# Altium schematic sheet Schematic.SchDoc
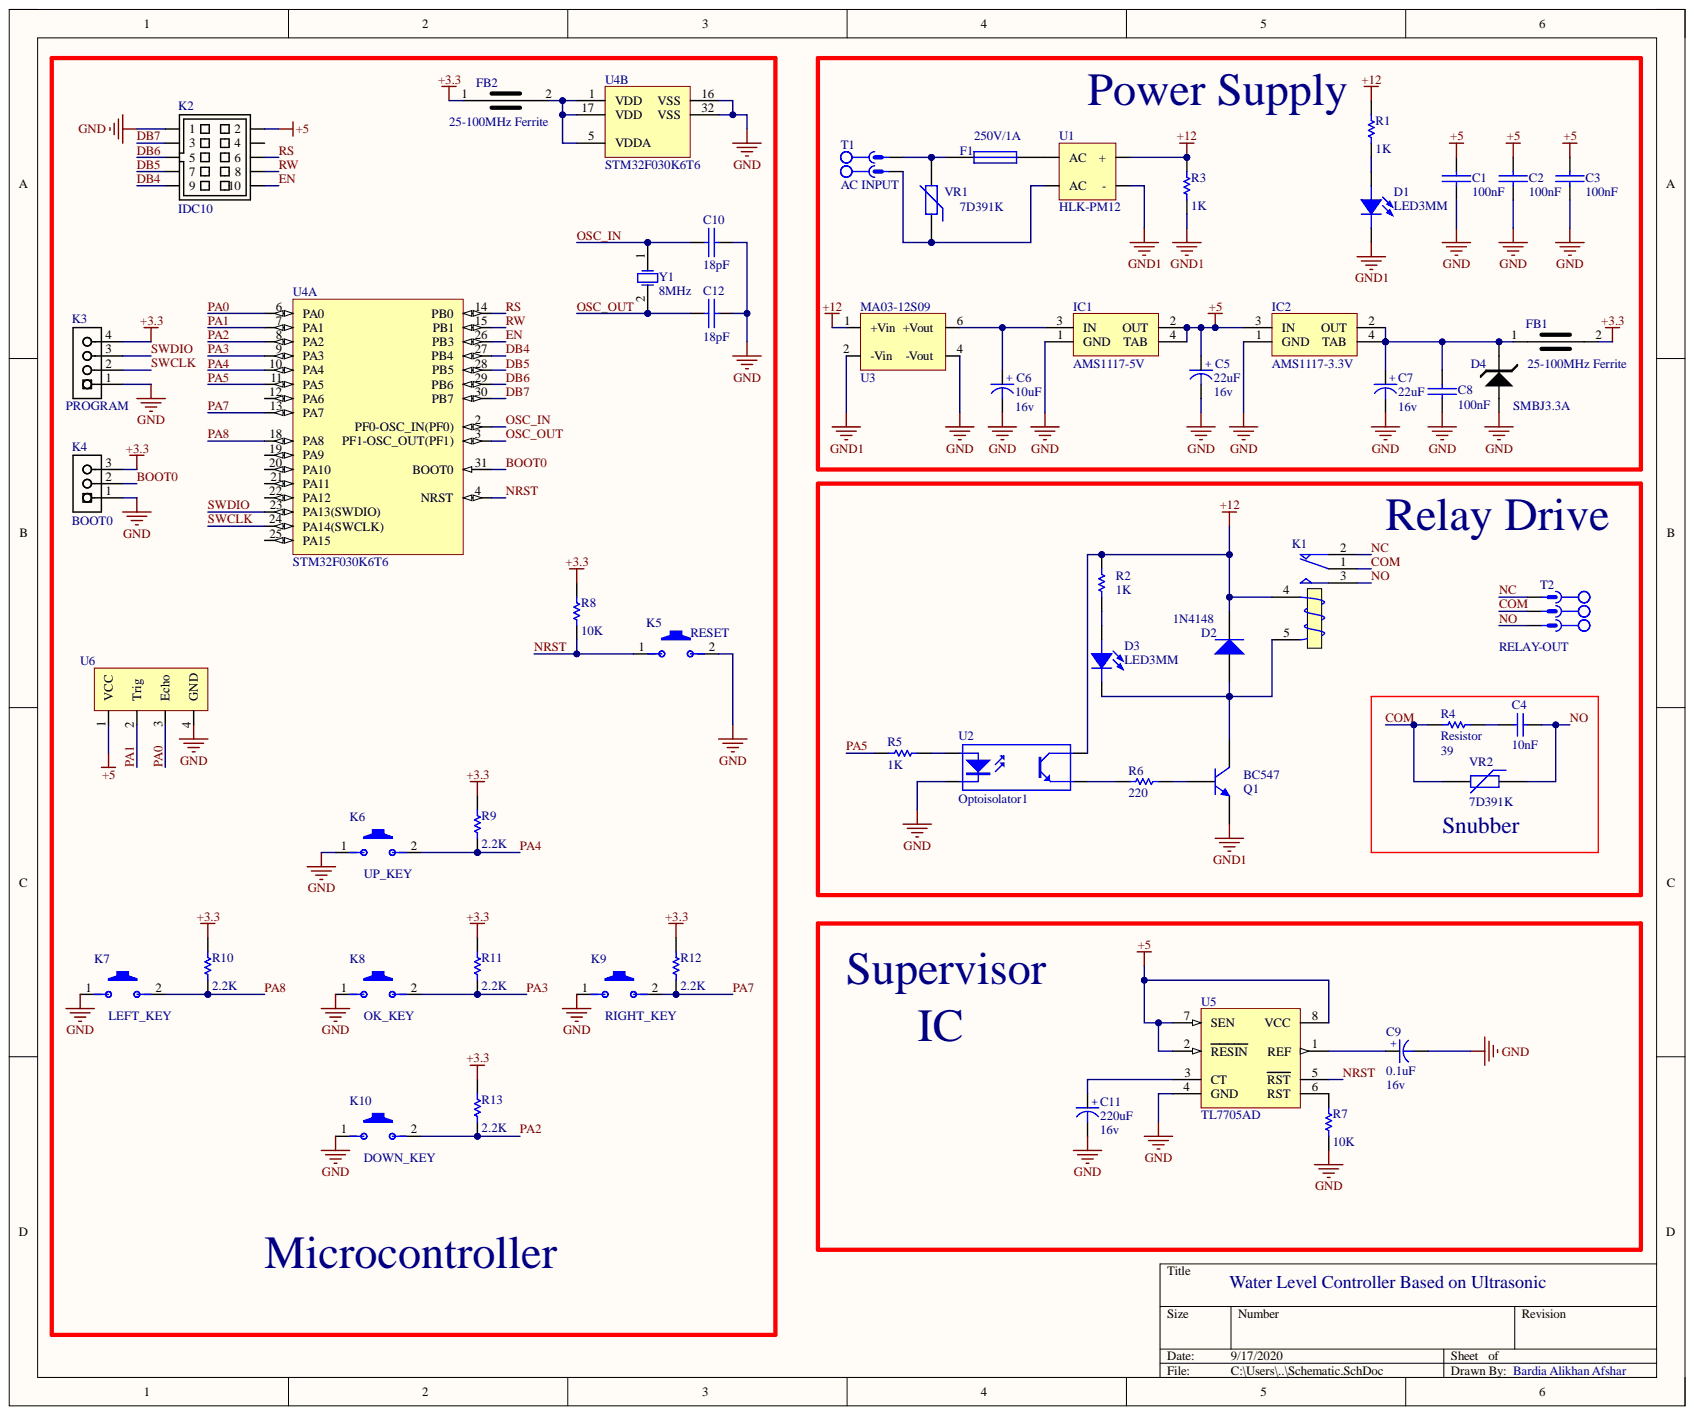
[diagram p0: Schematic.SchDoc]

## Parts
C1  value 100nF  [Cap_100nF]  (hidden comment: Capacitor.)
C2  value 100nF  [Cap_100nF]  (hidden comment: Capacitor.)
C3  value 100nF  [Cap_100nF]  (hidden comment: Capacitor.)
C4  10nF  [Cap-100nF-400V]
C5  value 22uF  Voltage: 16v  [cap-ele-02.]  (hidden comment: Capacitor_Ele)
C6  value 10uF  Voltage: 16v  [cap-ele-02.]  (hidden comment: Capacitor_Ele)
C7  value 22uF  Voltage: 16v  [cap-ele-02.]  (hidden comment: Capacitor_Ele)
C8  value 100nF  [Cap_100nF]  (hidden comment: Capacitor.)
C9  value 0.1uF  Voltage: 16v  [cap-ele-02.]  (hidden comment: Capacitor_Ele)
C10  value 18pF  [Cap_100nF]  (hidden comment: Capacitor.)
C11  value 220uF  Voltage: 16v  [Cap-Ele-03.]  (hidden comment: Capacitor_Ele)
C12  value 18pF  [Cap_100nF]  (hidden comment: Capacitor.)
D1  LED3MM  [LED3mm_GRN]
D2  1N4148  [DO_41]
D3  LED3MM  [LED3mm_GRN]
D4  SMBJ3.3A  [DO214AA]
F1  250V/1A  [PIN-W2/E2.8]
FB1  25-100MHz Ferrite  [Ferrite]
FB2  25-100MHz Ferrite  [Ferrite]
IC1  AMS1117-5V  [MP04A_N]
IC2  AMS1117-3.3V  [MP04A_N]
K1  (no value)  [Relay 18.85x15.35x15.70]
K2  IDC10  [IDC10-B]
K3  PROGRAM  [HEADER-1X4]
K4  BOOT0  [HEADER-1X3]
K5  RESET  [SW_4]
K6  UP_KEY  [SW_4]
K7  LEFT_KEY  [SW_4]
K8  OK_KEY  [SW_4]
K9  RIGHT_KEY  [SW_4]
K10  DOWN_KEY  [SW_4]
Q1  BC547  [TO-92 BENT]
R1  Resistor  value 1K  [Resistor_0.25w-0.3]
R2  Resistor  value 1K  [Resistor_0.25w-0.3]
R3  Resistor  value 1K  [Resistor_0.25w-0.3]
R4  Resistor  value 39  [Resistor_0.5W]
R5  Resistor  value 1K  [Resistor_0.25w-0.3]
R6  Resistor  value 220  [Resistor_0.25w-0.3]
R7  Resistor  value 10K  [Resistor_0.25w-0.3]
R8  Resistor  value 10K  [Resistor_0.25w-0.3]
R9  Resistor  value 2.2K  [Resistor_0.25w-0.3]
R10  Resistor  value 2.2K  [Resistor_0.25w-0.3]
R11  Resistor  value 2.2K  [Resistor_0.25w-0.3]
R12  Resistor  value 2.2K  [Resistor_0.25w-0.3]
R13  Resistor  value 2.2K  [Resistor_0.25w-0.3]
T1  AC INPUT  [MSTB 2,5/2-G-5,08]
T2  RELAY-OUT  [MSTB 2,5/3-G-5,08]
U1  HLK-PM12  [HLK-PM]
U2  Optoisolator1  [DIP-4]
U3  MA03-12S09  [MA03-12S09]
U4  STM32F030K6T6  [LQFP32]  (parts A,B)
U5  TL7705AD  [D008_N]
U6  JSN-SR04T  [JSN-SR04T-H]  (value not drawn)
VR1  7D391K  [07D XXX]
VR2  7D391K  [07D XXX]
Y1  8MHz  [XTAL Small]

## Nets
+3.3: FB1.2 FB2.1 K3.4 K4.3 R8.2 R9.2 R10.2 R11.2 R12.2 R13.2
+5: C1.1 C2.1 C3.1 C5.1 IC1.2 IC1.4 IC2.3 K2.2 U5.2 U5.7 U5.8 U6.1
+12: D2.2 K1.4 R1.2 R2.2 R3.2 U1.2 U2.4 U3.1
GND: C1.2 C2.2 C3.2 C5.2 C6.2 C7.2 C8.2 C9.2 C10.2 C11.2 C12.2 D4.A IC1.1 IC2.1 K2.1 K3.1 K4.1 K5.2 K6.1 K7.1 K8.1 K9.1 K10.1 R7.1 U2.2 U3.4 U4.16 U4.32 U5.4 U6.4
GND1: D1.2 Q1.3 R3.1 U1.3 U3.2
BOOT0: K4.2 U4.31
COM: K1.1 R4.1 T2.2 VR2.2
DB4: K2.9 U4.27
DB5: K2.7 U4.28
DB6: K2.5 U4.29
DB7: K2.3 U4.30
EN: K2.10 U4.26
NC: K1.2 T2.1
NO: C4.1 K1.3 T2.3 VR2.1
NRST: K5.1 R8.1 U4.4 U5.5
OSC_IN: C10.1 U4.2 Y1.1
OSC_OUT: C12.1 U4.3 Y1.2
PA0: U4.6 U6.3
PA1: U4.7 U6.2
PA2: K10.2 R13.1 U4.8
PA3: K8.2 R11.1 U4.9
PA4: K6.2 R9.1 U4.10
PA5: R5.2 U4.11
PA7: K9.2 R12.1 U4.13
PA8: K7.2 R10.1 U4.18
RS: K2.6 U4.14
RW: K2.8 U4.15
SWCLK: K3.2 U4.24
SWDIO: K3.3 U4.23
NetC4_2: C4.2 R4.2
NetC6_1: C6.1 IC1.3 U3.6
NetC7_1: C7.1 C8.1 D4.K FB1.1 IC2.2 IC2.4
NetC9_1: C9.1 U5.1
NetC11_1: C11.1 U5.3
NetD1_1: D1.1 R1.1
NetD2_1: D2.1 D3.2 K1.5 Q1.1
NetD3_1: D3.1 R2.1
NetF1_1: F1.1 T1.2 VR1.2
NetF1_2: F1.2 U1.0
NetFB2_2: FB2.2 U4.1 U4.5 U4.17
NetQ1_2: Q1.2 R6.1
NetR5_1: R5.1 U2.1
NetR6_2: R6.2 U2.3
NetR7_2: R7.2 U5.6
NetT1_1: T1.1 U1.1 VR1.1

Not connected: K2 4; U4 12 19 20 21 22 25

## Library data of the parts
C1-C3 C8 C10 C12 = Capacitor.: Capacitor; Component Kind: Standard; LatestRevisionDate: 17-Jul-2002; LatestRevisionNote: Re-released for DXP Platform.; Library Name: A.Mohebbi.IntLib; Publisher: Altium Limited
C4 = CAP CERAMIC TOTAL SIZE: 10nF/400V Polyester - DIP; Designer: Bardia Alikhan Afshar; Component Kind: Standard; Library Name: Altium.IntLib
C5-C7 C9 C11 = Capacitor_Ele.: Component Kind: Standard; Description: Capacitor_Ele; LatestRevisionDate: 17-Jul-2002; LatestRevisionNote: Re-released for DXP Platform.; Library Name: A.Mohebbi.IntLib; Publisher: Altium Limited
D1 D3 = LED 3MM: Light Emitting Diode; Designer: Bardia Alikhan Afshar; Component Kind: Standard; Library Name: Altium.IntLib
D2 = Diode_1N4007: Diode 1N4007; Component Kind: Standard; LatestRevisionDate: 17-Jul-2002; LatestRevisionNote: Re-released for DXP Platform.; Library Name: A.Mohebbi.IntLib; Publisher: Altium Limited
D4 = SMBJ12AVCL: Transient Surpression Diode (Transil); Manufacturer: STMicroelectronics; Manufacturer Part Number: SMBJ12CA-TR; Component Kind: Standard; Library Name: vh_altium_library.intLib; Supplier 1: Digi-Key; Supplier Part Number 1: 497-3002-2-ND
F1 = Fuse 1: Fuse; Component Kind: Standard; LatestRevisionDate: 17-Jul-2002; LatestRevisionNote: Re-released for DXP Platform.; Library Name: Miscellaneous Devices.IntLib; PackageDescription: Thru-Hole; 2 Leads; PackageReference: PIN-W2/E2.8; Published: 8-Jun-2000; Simulation: FUSE; Publisher: Altium Limited
FB1 FB2 = Ferrite: Component Kind: Standard; Library Name: Bardia.SchLib
IC1 IC2 = IC_LM1117IMP-x.x: x.x Volt, 800mA Low-Dropout Linear Regulator, 4-pin SOT-223; Manufacturer: National Semiconductor; Designer: Bardia Alikhan Afshar; ESD Sensetive: True/False; PackageTopMarking: XYTT*N05B; Category: Integrated Circuit; Code_IPC: SOT223-4; Component Kind: Standard; ComponentLink1Description: Datasheet; ComponentLink1URL: www.datasheet4u.com; ComponentLink2Description: Reference; ComponentLink2URL: http://www.national.com/pf/LM/LM1117.html; DatasheetVersion: Apr-2006; Library Name: SJ Altium Library.IntLib; Mounting Technology: Surface Mount; Operating Temperature: -55 ~ +125 (C); PackageDescription: Molded SOT-223, 6.50 x 3.56 x 1.6mm Body, 4 Lead, 2.3mm Pitch; PackageReference: MP04A; PinCount: 4; RoHS compliant: True/False; Status: Unrelease; Update: 6/5/2012
K1 = Relay_SPDT: Single-Pole Dual-Throw Relay; Designer: Bardia Alikhan Afshar; Component Kind: Standard; ComponentLink1Description: Datasheet; Mounting Technology: Through-Hole; Pin Count: 0; Update Date: 2017-3-1; Library Name: 2017AbbasBasereh9365731239 -ver1.IntLib
K2 = IDC10: BOXHEADER 10 PIN; Manufacturer Part Number: AWHW10G-0202-T-R; Connector Type: Header, Shrouded; Number of Positions: 10; Number of Positions Loaded: All; Pitch: 0.100" (2.54mm); Category: Connectors, Interconnects; Color: Gray; Component Kind: Standard; Contact Finish: Gold; Contact Finish Thickness: Flash; Contact Mating Length: -; Contact Type: Male Pin; Fastening Type: -; Features: -; Library Name: vh_altium_library.intLib; Mounting Type: Through Hole; Number of Rows: 2; Packaging: Tray; RoHS: RoHS Compliant; Row Spacing: 0.100" (2.54mm); Supplier: Digi-Key; Supplier 1: Digi-Key; Supplier Part Number: HRP10H-ND; Supplier Part Number 1: HRP10H-ND; Termination: Solder
K3 = HEADER-1X4: Component Kind: Standard; Library Name: vh_altium_library.intLib; Supplier 1: Digi-Key; Supplier Part Number 1: S1011E-36-ND
K4 = HEADER-1X3: Component Kind: Standard; Library Name: vh_altium_library.intLib; Supplier 1: Digi-Key; Supplier Part Number 1: S1011E-36-ND
K5-K10 = Push_Button: Design By: Bardia Alikhan Afshar; Component Kind: Standard; Library Name: Bardia.SchLib
Q1 = BC547: NPN General-purpose Transistor; Desc.: Plastic Medium-Power. Silicon NPN Transistors; Designer: Bardia Alikhan Afshar; Component Kind: Standard; Data: 27-12-2016; Library Name: Altium.IntLib; Part. No: BC547
R1-R3 R5-R13 = Resistor: Email: bardia.a.afshar@gmail.com; Component Kind: Standard; Description: Resistor; LatestRevisionNote: Re-released for puplic; Library Name: resistors.IntLib; Published: 17-Jun-2016; Publisher: Bardia Alikhan Afshar
R4 = Resistor: Email: bardia.a.afshar@gmail.com; Value: 39; Component Kind: Standard; Description: Resistor; LatestRevisionNote: Re-released for puplic; Library Name: resistors.IntLib; Published: 17-Jun-2016; Publisher: Bardia Alikhan Afshar
T1 = CON_Phoenix_MSTB_02: 2 Pitch: 5.08 mm Color: green Contact surface: Tin Assembly; Manufacturer: PHOENIX CONTACT; Connector type: PCB Terminal Block; designer: Bardia Alikhan Afshar; Max Current: 12 A; Positions: 2; Voltage: 320 V; Category: Connector; Component Kind: Standard; ComponentLink1Description: Header, Nominal current: 12 A Rated voltage (III/2): 320 V Number of positions: 2 Pitch: 5.08 mm Color: green Contact surface: Tin Assembly: Soldering  DESIGNER:ABBAS BASEREH; ComponentLink2Description: Reference; Mounting Technology: Through-Hole; Pin Count: 2; Revision 1: 2017-3-1; Signal Integrity: CON-2P; Status: Unreleased; Library Name: 2017AbbasBasereh9365731239 -ver1.IntLib
T2 = CON_Phoenix_MSTB_03: 3 Pitch: 5.08 mm Color: green Contact surface: Tin Assembly; Manufacturer: PHOENIX CONTACT; Connector type: PCB Terminal Block; designer: Bardia Alikhan Afshar; Max Current: 12 A; Positions: 3; Voltage: 320 V; Category: Connector; Component Kind: Standard; ComponentLink1Description: Header, Nominal current: 12 A Rated voltage (III/2): 320 V Number of positions: 3 Pitch: 5.08 mm Color: green Contact surface: Tin Assembly: Soldering  DESIGNER:ABBAS BASEREH; ComponentLink2Description: Reference; Mounting Technology: Through-Hole; Pin Count: 3; Revision 1: 2017-3-1; Signal Integrity: CON-3P; Status: Unreleased; Library Name: 2017AbbasBasereh9365731239 -ver1.IntLib
U1 = HLK-PM: AC/DC Converter; Component Kind: Standard; Library Name: Bardia.SchLib
U2 = Optoisolator1: 4-Pin Phototransistor Optocoupler; Component Kind: Standard; DatasheetVersion: Nov-2003; LatestRevisionDate: 04-Mar-2005; LatestRevisionNote: Sch pins 3 & 4 Swapped.; Library Name: Miscellaneous Devices.IntLib; PackageReference: DIP-4; Published: 24-Mar-1999; Publisher: Altium Limited
U3 = MA03-12S0x: DC/DC Converter; Component Kind: Standard; Library Name: Bardia.SchLib
U4 = STM32F030K6T6: ARM Cortex-M0 32-bit MCU, 32 KB Flash, 4 KB Internal RAM, 26 I/Os, 32-pin LQFP, -40 to 85 degC, Tray; FLASH Size (Prog) (kB): 32; I/Os (High Current): 26; Internal RAM Size (kB): 4; Operating Frequency(F) (Processor speed) (MHz): 48; Supply Voltage(Vcc) min (V): 2.4; Component Kind: Standard; ComponentLink1Description: Manufacturer URL; ComponentLink1URL: http://www.st.com/web/en/home.html; ComponentLink2Description: Datasheet; ComponentLink2URL: http://www.st.com/st-web-ui/static/active/en/resource/technical/document/datasheet/DM00088500.pdf; ComponentLink3Description: Package Specification; ComponentLink3URL: http://www.st.com/st-web-ui/static/active/en/resource/technical/document/datasheet/DM00088500.pdf; Core: ARM Cortex-M0; DatasheetVersion: Rev. 1; Mounting Technology: Surface Mount; PackageDescription: 32-Pin Low Profile Quad Flat Package, 7 x 7 mm Body, 0.8 mm Pitch; PackageReference: LQFP32; PackageVersion: Rev. 1, 07/2013; Packing: Tray; PartNumber: STM32F030K6T6; Supplier 1: Digi-Key; Supplier 2: Newark; Supplier 3: Mouser; Supplier Part Number 1: 497-14046-ND; Supplier Part Number 2: 19X6194; Supplier Part Number 3: 511-STM32F030K6T6; Library Name: Bardia.SchLib
U5 = TL7705AD: Supply Voltage Supervisor; Code_IPC: SOIC127P600-8; Component Kind: Standard; ComponentLink1Description: Manufacturer Link; ComponentLink1URL: http://www.ti.com/; DatasheetVersion: Oct-1988; LatestRevisionDate: 13-Apr-2006; LatestRevisionNote: IPC-7351 Footprint Added.; PackageDescription: 8-Pin Small Outline Integrated Circuit 1.27 mm Pitch; PackageReference: D008; PackageVersion: Jan-1998; Published: 15-Apr-2002; Library Name: Bardia.SchLib; Publisher: Altium Limited
U6 = JSN-SR04T: Ultrasonic Range Finder; Component Kind: Standard; Library Name: Bardia.SchLib
VR1 = VARISTOR (VDR): VARISTORS; Designer: Bardia Alikhan Afshar; Models: MOV-VDR-COV; Component Kind: Standard; Data: 2017-1-5; VALUE: 7D391K; Library Name: 2017AbbasBasereh9365731239 -ver1.IntLib
VR2 = VARISTOR (VDR): VARISTORS; Designer: Abbas Basereh; Models: MOV-VDR-COV; Component Kind: Standard; Data: 2017-1-5; Library Name: 2017AbbasBasereh9365731239 -ver1.IntLib; VALUE: 7D391K; PUBLISHER: Bardia Alikhan Afshar
Y1 = XTAL: XTAL Crystal; Component Kind: Standard; Design Date: 11/04/2011; Library Name: My Library.IntLib; Value: 8MHz
